# Altium schematic sheet main-board.SchDoc(main-board)
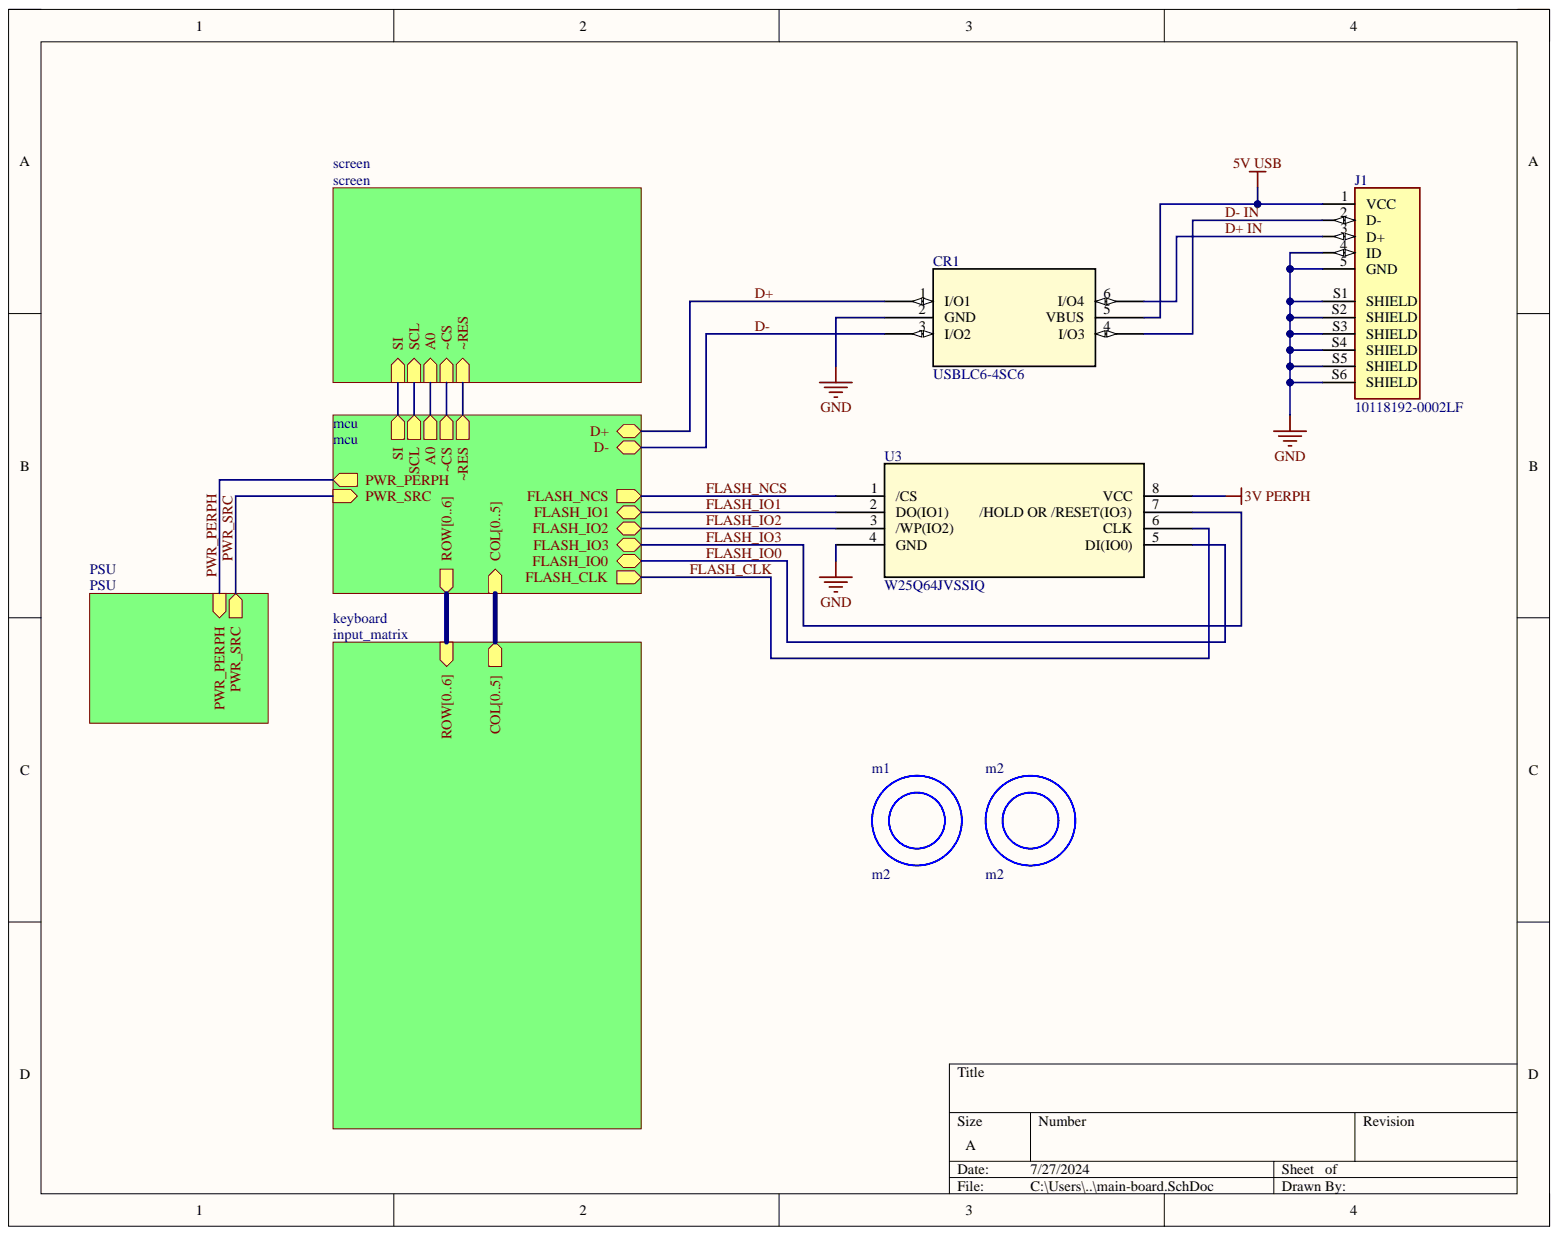
[diagram p0: main-board.SchDoc]

## Parts
CR1  USBLC6-4SC6  [SOT23-6L_STM]
J1  10118192-0002LF  [AMPHENOL_10118192-0002LF]
m1  m2  [m2]
m2  m2  [m2]
U3  W25Q64JVSSIQ  [SOIC-8_5P28X5P28_WIN]

## Nets
3V PERPH: U3.8(VCC)
5V USB: CR1.5(VBUS) J1.1(VCC)
GND: CR1.2(GND) J1.4(ID) J1.5(GND) J1.S1(SHIELD) J1.S2(SHIELD) J1.S3(SHIELD) J1.S4(SHIELD) J1.S5(SHIELD) J1.S6(SHIELD) U3.4(GND)
D+: CR1.1(I/O1)
D+ IN: CR1.6(I/O4) J1.3(D+)
D-: CR1.3(I/O2)
D- IN: CR1.4(I/O3) J1.2(D-)
FLASH_CLK: U3.6(CLK)
FLASH_IO0: U3.5(DI(IO0))
FLASH_IO1: U3.2(DO(IO1))
FLASH_IO2: U3.3(/WP(IO2))
FLASH_IO3: U3.7
FLASH_NCS: U3.1(/CS)
A0:
COL0:
COL1:
COL2:
COL3:
COL4:
COL5:
PWR_PERPH:
PWR_SRC:
ROW0:
ROW1:
ROW2:
ROW3:
ROW4:
ROW5:
ROW6:
SCL:
SI:
~CS:
~RES:

## Library data of the parts
CR1 = USBLC6-4SC6: No Description Available; Mfr_Name: STMicroelectronics; Component Kind: Standard; Library Name: USBLC6-4SC6.IntLib; RefDes: RefDes; Type: Type
J1 = 10118192-0002LF: Micro USB 2.0, Input Output Connectors, B TYPE RECEPTACLE without flange; MF: Amphenol ICC; Check_prices: https://www.snapeda.com/parts/10118192-0002LF/Amphenol+FCI/view-part/?ref=eda; Component Kind: Standard; Library Name: 10118192-0002LF.IntLib; MP: 10118192-0002LF; Package: None; SnapEDA_Link: https://www.snapeda.com/parts/10118192-0002LF/Amphenol+FCI/view-part/?ref=snap
U3 = W25Q64JVSSIQ: No Description Available; Mfr_Name: Winbond; Component Kind: Standard; Library Name: W25Q64JVSSIQ.SchLib; RefDes: RefDes; Type: Type
m1 m2 = m2: Component Kind: Standard; Library Name: m2.SchLib
